# Altium schematic sheet HDMI.SchDoc(HDMI)
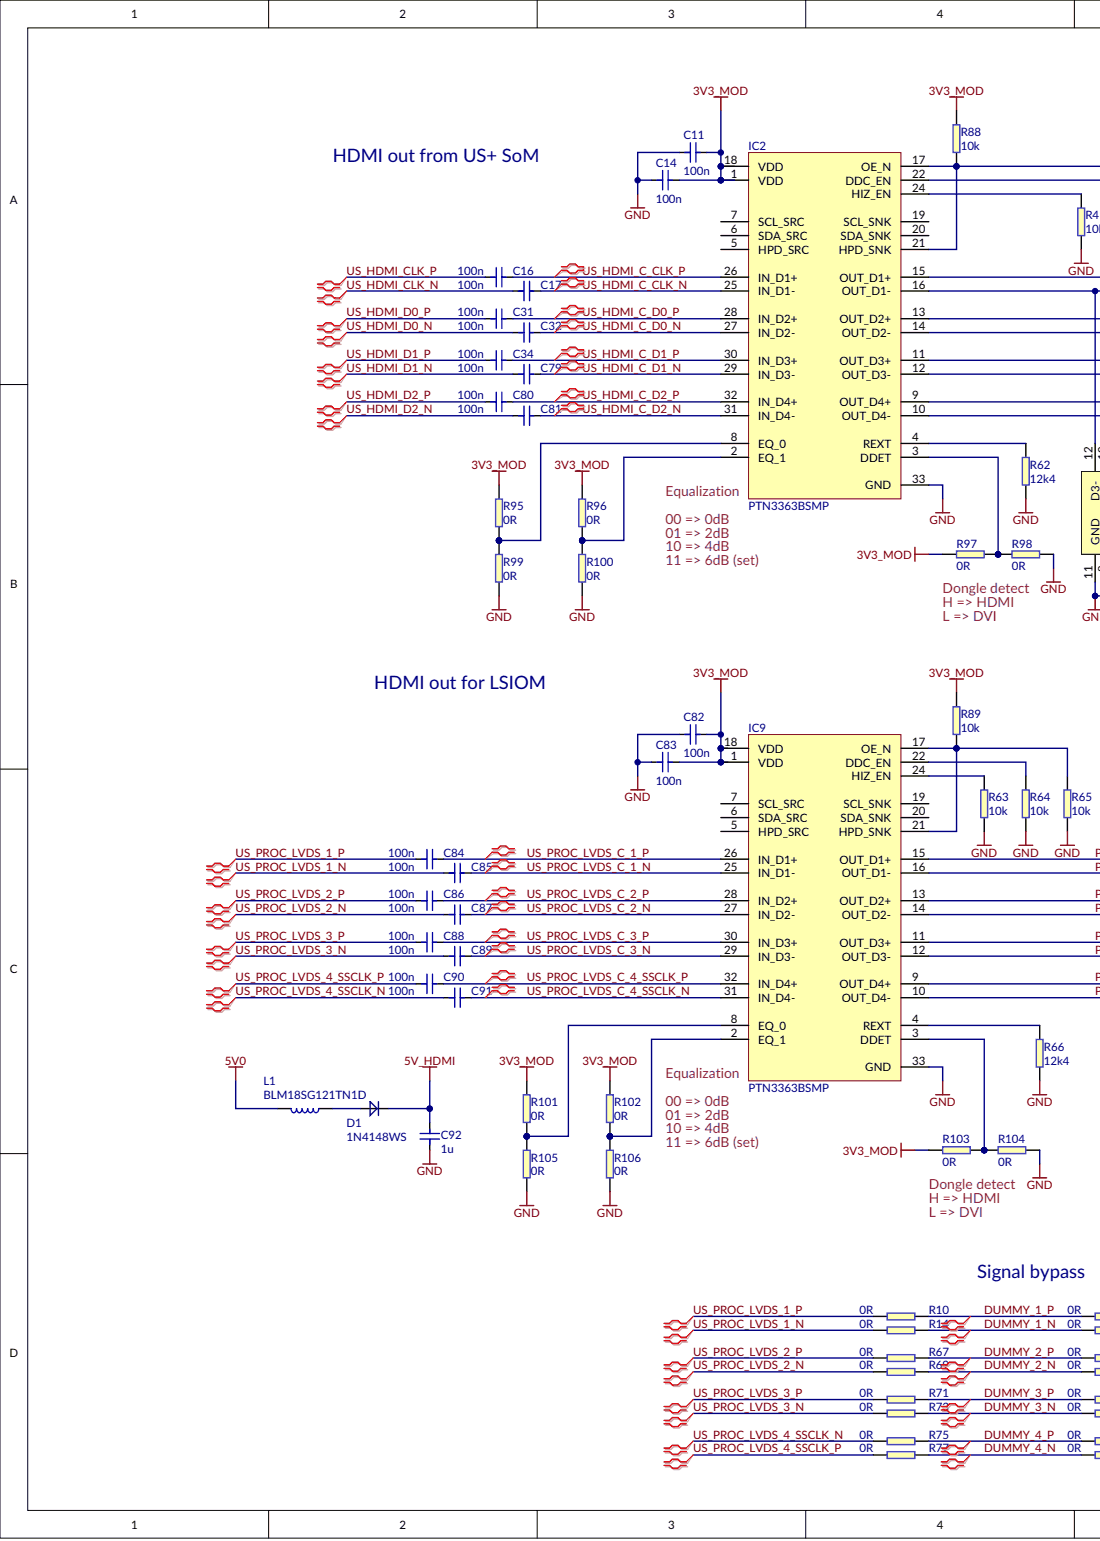
[diagram p0: HDMI.SchDoc, left]
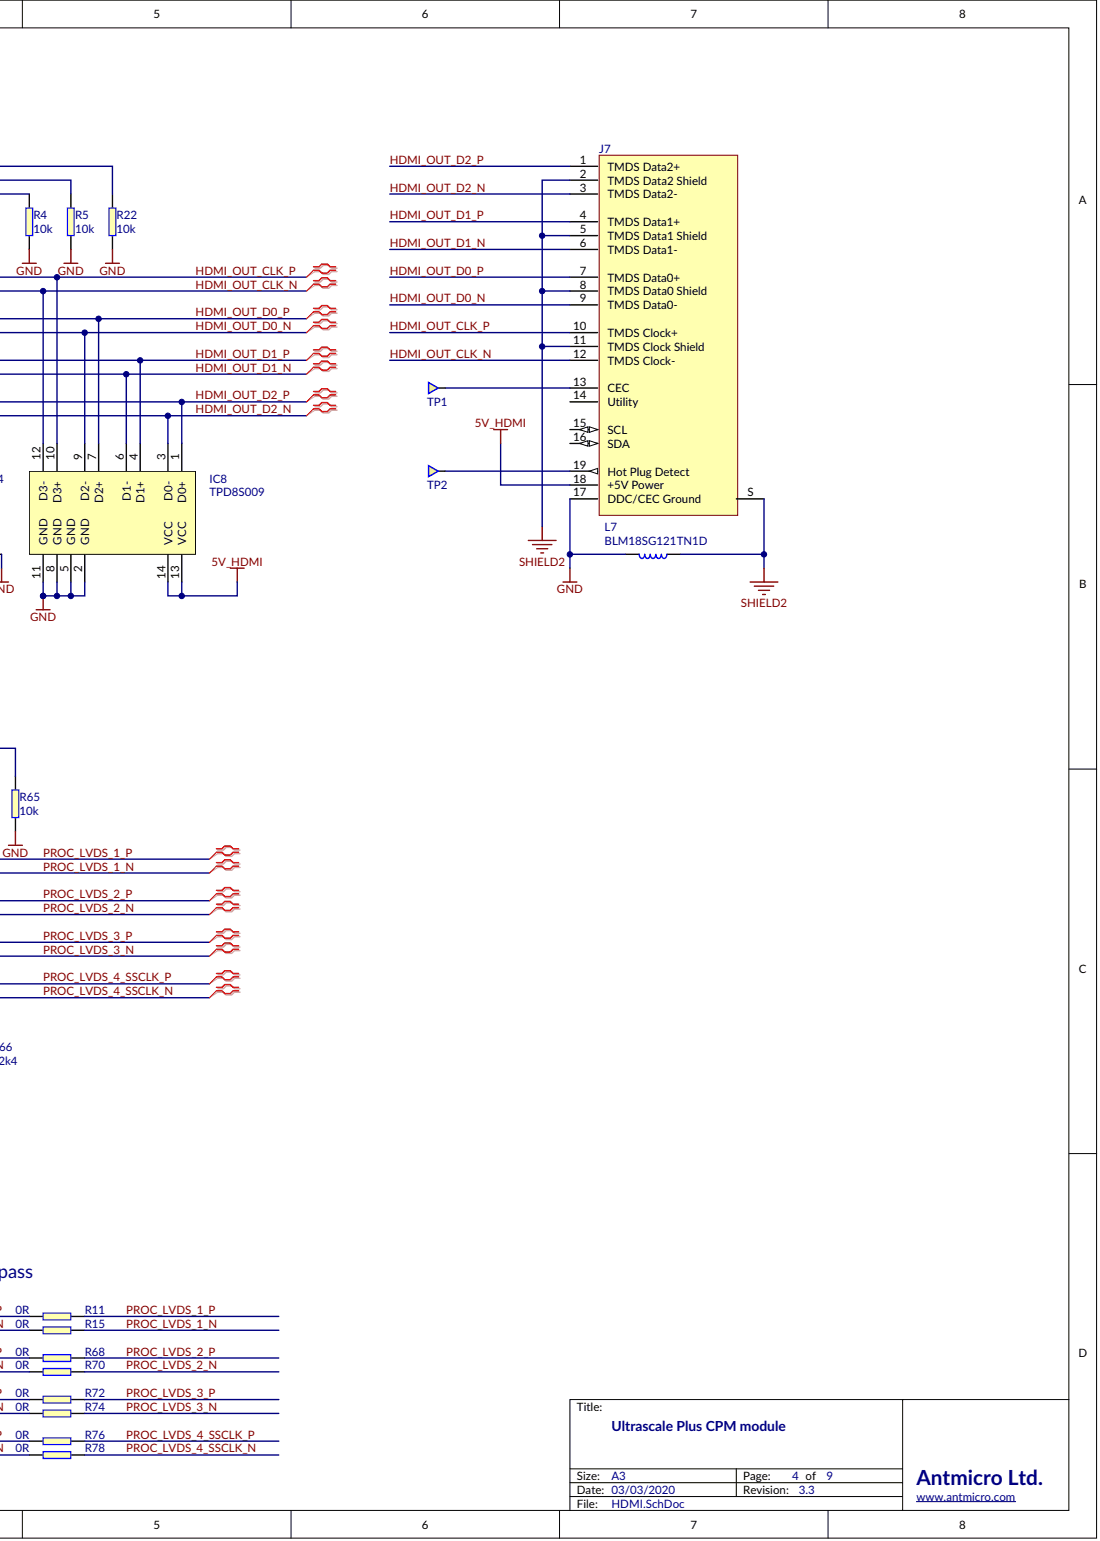
[diagram p1: HDMI.SchDoc, right]

## Parts
C11  100n
C14  100n
C16  100n
C17  100n
C31  100n
C32  100n
C34  100n
C79  100n
C80  100n
C81  100n
C82  100n
C83  100n
C84  100n
C85  100n
C86  100n
C87  100n
C88  100n
C89  100n
C90  100n
C91  100n
C92  1u
D1  1N4148WS
IC2  PTN3363BSMP
IC8  TPD8S009
IC9  PTN3363BSMP
J7  (no value)
L1  BLM18SG121TN1D
L7  BLM18SG121TN1D
R4  10k
R5  10k
R10  0R
R11  0R
R14  0R
R15  0R
R22  10k
R62  12k4
R63  10k
R64  10k
R65  10k
R66  12k4
R67  0R
R68  0R
R69  0R
R70  0R
R71  0R
R72  0R
R73  0R
R74  0R
R75  0R
R76  0R
R77  0R
R78  0R
R88  10k
R89  10k
R95  0R
R96  0R
R97  0R
R98  0R
R99  0R
R100  0R
R101  0R
R102  0R
R103  0R
R104  0R
R105  0R
R106  0R
TP1  *  (value not drawn)
TP2  *  (value not drawn)

## Nets
3V3_MOD: C11.2 C14.2 C82.2 C83.2 IC2.1(VDD) IC2.18(VDD) IC9.1(VDD) IC9.18(VDD) R88.1 R89.1 R95.2 R96.2 R97.2 R101.2 R102.2 R103.2
5V0: L1.1
5V_HDMI: C92.2 D1.C IC8.13(VCC) IC8.14(VCC) J7.18(+5V_Power)
GND: C11.1 C14.1 C82.1 C83.1 C92.1 IC2.33(GND) IC8.2(GND) IC8.5(GND) IC8.8(GND) IC8.11(GND) IC9.33(GND) J7.17 L7.1 R4.2 R5.2 R22.2 R62.1 R63.2 R64.2 R65.2 R66.1 R98.1 R99.1 R100.1 R104.1 R105.1 R106.1
SHIELD2: J7.2(TMDS_Data2_Shield) J7.5(TMDS_Data1_Shield) J7.8(TMDS_Data0_Shield) J7.11(TMDS_Clock_Shield) J7.S L7.2
DUMMY_1_N: R14.2 R15.1
DUMMY_1_P: R10.2 R11.1
DUMMY_2_N: R69.2 R70.1
DUMMY_2_P: R67.2 R68.1
DUMMY_3_N: R73.2 R74.1
DUMMY_3_P: R71.2 R72.1
DUMMY_4_N: R77.2 R78.1
DUMMY_4_P: R75.2 R76.1
HDMI_OUT_CLK_N: IC2.16(OUT_D1-) IC8.12(D3-) J7.12(TMDS_Clock-)
HDMI_OUT_CLK_P: IC2.15(OUT_D1+) IC8.10(D3+) J7.10(TMDS_Clock+)
HDMI_OUT_D0_N: IC2.14(OUT_D2-) IC8.9(D2-) J7.9(TMDS_Data0-)
HDMI_OUT_D0_P: IC2.13(OUT_D2+) IC8.7(D2+) J7.7(TMDS_Data0+)
HDMI_OUT_D1_N: IC2.12(OUT_D3-) IC8.6(D1-) J7.6(TMDS_Data1-)
HDMI_OUT_D1_P: IC2.11(OUT_D3+) IC8.4(D1+) J7.4(TMDS_Data1+)
HDMI_OUT_D2_N: IC2.10(OUT_D4-) IC8.3(D0-) J7.3(TMDS_Data2-)
HDMI_OUT_D2_P: IC2.9(OUT_D4+) IC8.1(D0+) J7.1(TMDS_Data2+)
PROC_LVDS_1_N: IC9.16(OUT_D1-) R15.2
PROC_LVDS_1_P: IC9.15(OUT_D1+) R11.2
PROC_LVDS_2_N: IC9.14(OUT_D2-) R70.2
PROC_LVDS_2_P: IC9.13(OUT_D2+) R68.2
PROC_LVDS_3_N: IC9.12(OUT_D3-) R74.2
PROC_LVDS_3_P: IC9.11(OUT_D3+) R72.2
PROC_LVDS_4_SSCLK_N: IC9.10(OUT_D4-) R78.2
PROC_LVDS_4_SSCLK_P: IC9.9(OUT_D4+) R76.2
US_HDMI_C_CLK_N: C17.2 IC2.25(IN_D1-)
US_HDMI_C_CLK_P: C16.2 IC2.26(IN_D1+)
US_HDMI_C_D0_N: C32.2 IC2.27(IN_D2-)
US_HDMI_C_D0_P: C31.2 IC2.28(IN_D2+)
US_HDMI_C_D1_N: C79.2 IC2.29(IN_D3-)
US_HDMI_C_D1_P: C34.2 IC2.30(IN_D3+)
US_HDMI_C_D2_N: C81.2 IC2.31(IN_D4-)
US_HDMI_C_D2_P: C80.2 IC2.32(IN_D4+)
US_HDMI_CLK_N: C17.1
US_HDMI_CLK_P: C16.1
US_HDMI_D0_N: C32.1
US_HDMI_D0_P: C31.1
US_HDMI_D1_N: C79.1
US_HDMI_D1_P: C34.1
US_HDMI_D2_N: C81.1
US_HDMI_D2_P: C80.1
US_PROC_LVDS_1_N: C85.1 R14.1
US_PROC_LVDS_1_P: C84.1 R10.1
US_PROC_LVDS_2_N: C87.1 R69.1
US_PROC_LVDS_2_P: C86.1 R67.1
US_PROC_LVDS_3_N: C89.1 R73.1
US_PROC_LVDS_3_P: C88.1 R71.1
US_PROC_LVDS_4_SSCLK_N: C91.1 R75.1
US_PROC_LVDS_4_SSCLK_P: C90.1 R77.1
US_PROC_LVDS_C_1_N: C85.2 IC9.25(IN_D1-)
US_PROC_LVDS_C_1_P: C84.2 IC9.26(IN_D1+)
US_PROC_LVDS_C_2_N: C87.2 IC9.27(IN_D2-)
US_PROC_LVDS_C_2_P: C86.2 IC9.28(IN_D2+)
US_PROC_LVDS_C_3_N: C89.2 IC9.29(IN_D3-)
US_PROC_LVDS_C_3_P: C88.2 IC9.30(IN_D3+)
US_PROC_LVDS_C_4_SSCLK_N: C91.2 IC9.31(IN_D4-)
US_PROC_LVDS_C_4_SSCLK_P: C90.2 IC9.32(IN_D4+)
NetD1_A: D1.A L1.2
NetIC2_2: IC2.2(EQ_1) R96.1 R100.2
NetIC2_3: IC2.3(DDET) R97.1 R98.2
NetIC2_4: IC2.4(REXT) R62.2
NetIC2_8: IC2.8(EQ_0) R95.1 R99.2
NetIC2_17: IC2.17(OE_N) IC2.21(HPD_SNK) R22.1 R88.2
NetIC2_22: IC2.22(DDC_EN) R5.1
NetIC2_24: IC2.24(HIZ_EN) R4.1
NetIC9_2: IC9.2(EQ_1) R102.1 R106.2
NetIC9_3: IC9.3(DDET) R103.1 R104.2
NetIC9_4: IC9.4(REXT) R66.2
NetIC9_8: IC9.8(EQ_0) R101.1 R105.2
NetIC9_17: IC9.17(OE_N) IC9.21(HPD_SNK) R65.1 R89.2
NetIC9_22: IC9.22(DDC_EN) R64.1
NetIC9_24: IC9.24(HIZ_EN) R63.1
NetJ7_13: J7.13(CEC) TP1.1
NetJ7_19: J7.19(Hot_Plug_Detect) TP2.1

Not connected: IC2 5(HPD_SRC) 6(SDA_SRC) 7(SCL_SRC) 19(SCL_SNK) 20(SDA_SNK); IC9 5(HPD_SRC) 6(SDA_SRC) 7(SCL_SRC) 19(SCL_SNK) 20(SDA_SNK); J7 14(Utility) 15(SCL) 16(SDA)

## Library data of the parts
C11 C14 C82 C83 = (no symbol name): Part Number: C_100n_0603; Manufacturer: WALSIN; Part Symbol: 0603B104K500CT; Value: 100n; Supplier 1: Farnell; Supplier Part Number 1: 2496834; ClassName: DisplayPort
C16 C17 C31 C32 C34 C79-C81 C84-C91 = (no symbol name): Part Number: C_100n_0402; Manufacturer: MULTICOMP; Part Symbol: MC0402X104M100CT; Value: 100n; Supplier 1: Farnell; Supplier Part Number 1: 2309011
C92 = (no symbol name): Part Number: C_1u_0603; Manufacturer: MULTICOMP; Part Symbol: MC0603X105M6R3CT; Value: 1u; Supplier 1: Farnell; Supplier Part Number 1: 2309013
D1 = (no symbol name): Manufacturer: MULTICOMP; Part Number: 1N4148WS; Value: 1N4148WS; Supplier 1: Farnell; Supplier Part Number 1: 1466524; Part Symbol: 1N4148WS
IC2 IC9 = (no symbol name): Manufacturer: NXP; Part Number: PTN3363BSMP; Value: PTN3363BSMP; Supplier 1: Digi-Key; Supplier Part Number 1: 568-11580-1-ND; Part Symbol: PTN3363BSMP
IC8 = (no symbol name): Manufacturer: TEXAS INSTRUMENTS; Part Symbol: TPD8S009DSMR; Part Number: TPD8S009; Value: TPD8S009; Supplier 1: Farnell; Supplier Part Number 1: 1772003; Supplier 2: Mouser; Supplier Part Number 2: 595-TPD8S009DSMR; Supplier 3: Digi-Key; Supplier Part Number 3: 296-23829-1-ND; ClassName: DisplayPort
J7 = (no symbol name): Part Number: CON-Molex-471511001; Manufacturer: MOLEX; Part Symbol: 47151-1001; Supplier 1: Farnell; Supplier Part Number 1: 1516661
L1 L7 = (no symbol name): Manufacturer: MURATA; Rated Current: 3A; Part Number: BLM18SG121TN1D; Value: BLM18SG121TN1D; Supplier 1: Farnell; Supplier Part Number 1: 1515752; Part Symbol: BLM18SG121TN1D
R4 R5 R22 R63-R65 R88 R89 = (no symbol name): Part Number: R_10k_0402; Manufacturer: MULTICOMP; Part Symbol: MCMR04X1002FTL; Tolerance: 1%; Value: 10k; Supplier 1: Farnell; Supplier Part Number 1: 2072517
R10 R11 R14 R15 R67-R78 = (no symbol name): Part Number: R_0R_0402; Manufacturer: MULTICOMP; Part Symbol: MCMR04X000 PTL; Supplier 1: Farnell; Supplier Part Number 1: 2072513; Value: 0R
R62 R66 = (no symbol name): Part Number: R_12k4_0603_1%; Manufacturer: Vishay; Part Symbol: CRCW060312K4FKEA; Tolerance: 1%; Value: 12k4; Supplier 1: Farnell; Supplier Part Number 1: 1652836
R95-R106 = (no symbol name): PartNumber: R_0R_0402; Manufacturer: MULTICOMP; Value: 0R; Supplier1: Farnell; SupplierPartNumber1: 2072513
TP1 TP2 = (no symbol name): Part Number: Testpad
